# Altium schematic sheet RelayExpan.SchDoc
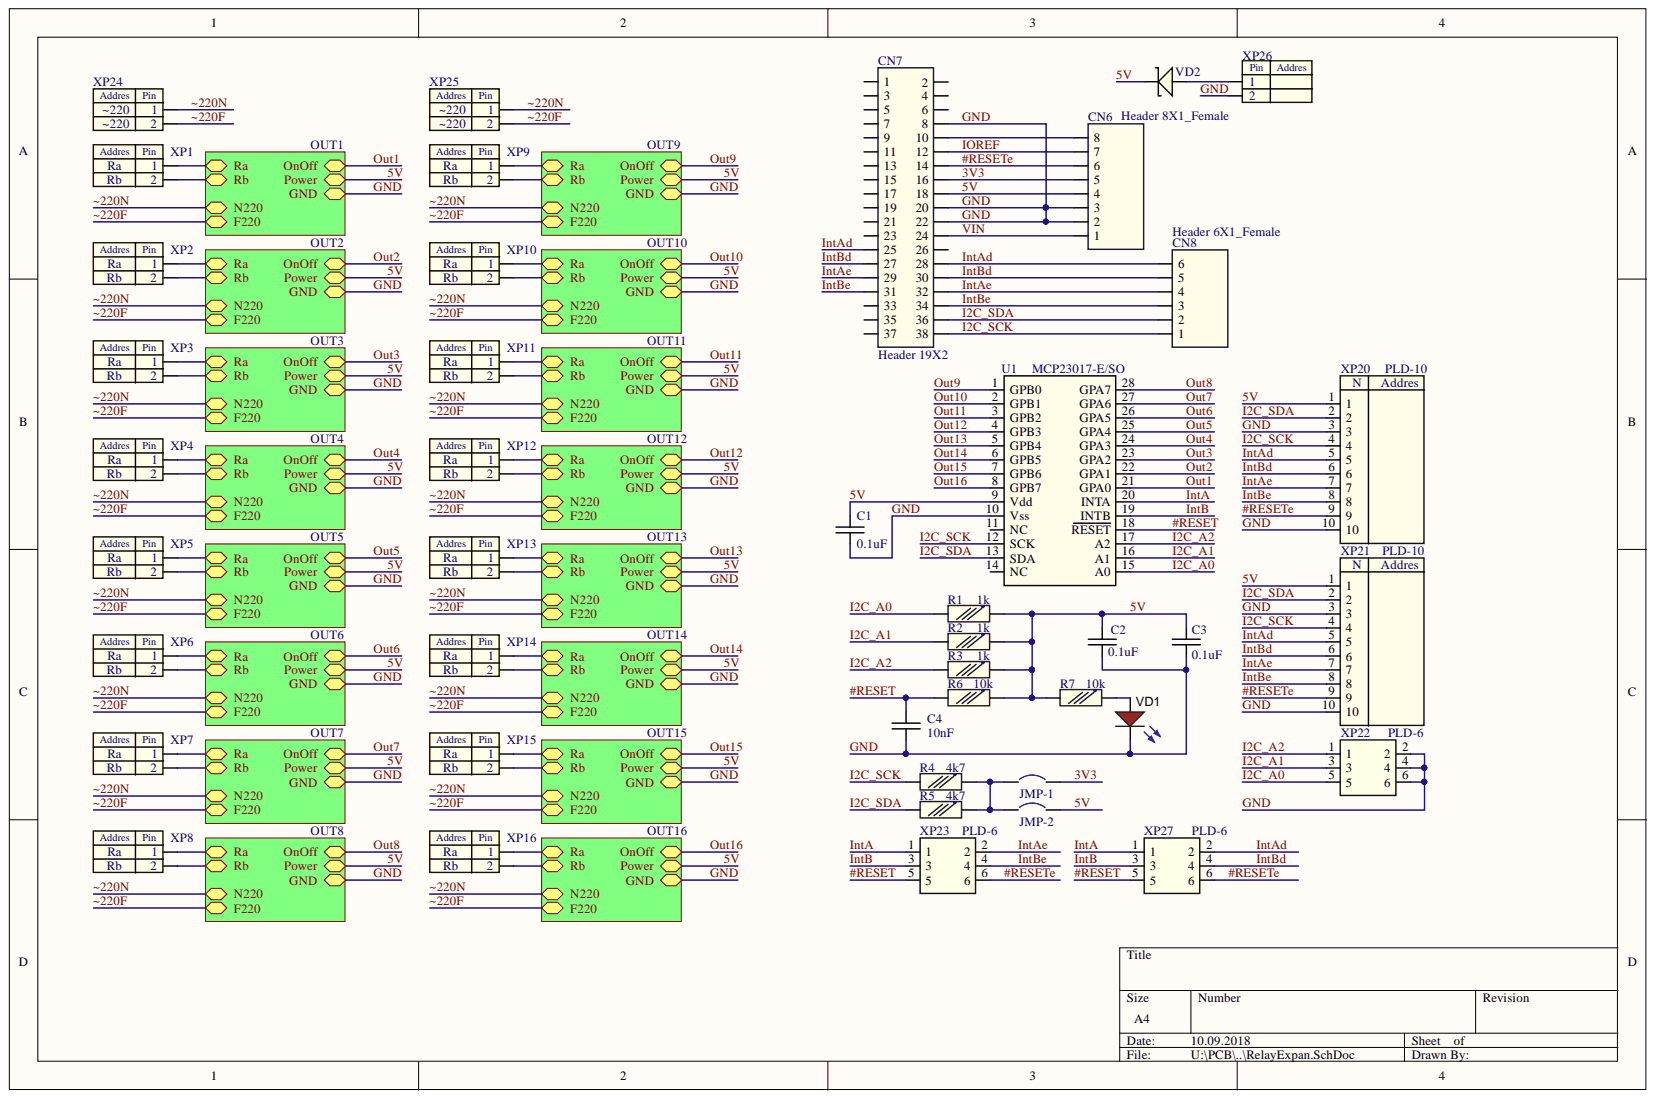
[diagram p0: RelayExpan.SchDoc]

## Parts
C1  0.1uF  [C0603]
C2  0.1uF  [C0603]
C3  0.1uF  [C0603]
C4  10nF  [C0603]
CN6  Header 8X1_Female  [1*8P_FEMALE]
CN7  Header 19X2  [2*19P]
CN8  Header 6X1_Female  [1*6P_FEMALE]
JMP-1  Circuit Breaker  [JP2_SMD]  (value not drawn)
JMP-2  Circuit Breaker  [JP2_SMD]  (value not drawn)
R1  1k  [R0603H-102]
R2  1k  [R0603H-102]
R3  1k  [R0603H-102]
R4  4k7  [R0603H-472]
R5  4k7  [R0603H-472]
R6  10k  [R0603H-103]
R7  10k  [R0603H-103]
U1  MCP23017-E/SO  [SOIC-28-300-MCP23017]
VD1  Red  [Led0603Red]  (value not drawn)
VD2  MBR0530  [SOD-123 - MBR0520T]  (value not drawn)
XP1  DG301-5.0  [DG301-5.0]  (value not drawn)
XP2  DG301-5.0  [DG301-5.0]  (value not drawn)
XP3  DG301-5.0  [DG301-5.0]  (value not drawn)
XP4  DG301-5.0  [DG301-5.0]  (value not drawn)
XP5  DG301-5.0  [DG301-5.0]  (value not drawn)
XP6  DG301-5.0  [DG301-5.0]  (value not drawn)
XP7  DG301-5.0  [DG301-5.0]  (value not drawn)
XP8  DG301-5.0  [DG301-5.0]  (value not drawn)
XP9  DG301-5.0  [DG301-5.0]  (value not drawn)
XP10  DG301-5.0  [DG301-5.0]  (value not drawn)
XP11  DG301-5.0  [DG301-5.0]  (value not drawn)
XP12  DG301-5.0  [DG301-5.0]  (value not drawn)
XP13  DG301-5.0  [DG301-5.0]  (value not drawn)
XP14  DG301-5.0  [DG301-5.0]  (value not drawn)
XP15  DG301-5.0  [DG301-5.0]  (value not drawn)
XP16  DG301-5.0  [DG301-5.0]  (value not drawn)
XP20  PLD-10  [PLD-10]
XP21  PLD-10  [PLD-10]
XP22  PLD-6  [PLD-6]
XP23  PLD-6  [PLD-6]
XP24  DG301-5.0  [DG301-5.0]  (value not drawn)
XP25  DG301-5.0  [DG301-5.0]  (value not drawn)
XP26  DG301-5.0  [DG301-5.0]  (value not drawn)
XP27  PLD-6  [PLD-6]

## Nets
3V3: CN6.5 CN7.16 JMP-1.2
5V: C1.2 C2.2 C3.2 CN6.4 CN7.18 JMP-2.2 R1.1 R2.1 R3.1 R6.1 R7.2 U1.9(Vdd) VD2.1 XP20.1 XP21.1
#RESET: C4.2 R6.2 U1.18(RESET) XP23.5 XP27.5
#RESETE: CN6.6 CN7.14 XP20.9 XP21.9 XP23.6 XP27.6
GND: C1.1 C2.1 C3.1 C4.1 CN6.2 CN6.3 CN7.8 CN7.20 CN7.22 U1.10(Vss) VD1.2 XP20.3 XP20.10 XP21.3 XP21.10 XP22.2 XP22.4 XP22.6 XP26.2
I2C_A0: R1.2 U1.15(A0) XP22.5
I2C_A1: R2.2 U1.16(A1) XP22.3
I2C_A2: R3.2 U1.17(A2) XP22.1
I2C_SCK: CN7.38 CN8.1 R4.2 U1.12(SCK) XP20.4 XP21.4
I2C_SDA: CN7.36 CN8.2 R5.2 U1.13(SDA) XP20.2 XP21.2
INTA: U1.20(INTA) XP23.1 XP27.1
INTAD: CN7.25 CN7.28 CN8.6 XP20.5 XP21.5 XP27.2
INTAE: CN7.29 CN7.32 CN8.4 XP20.7 XP21.7 XP23.2
INTB: U1.19(INTB) XP23.3 XP27.3
INTBD: CN7.27 CN7.30 CN8.5 XP20.6 XP21.6 XP27.4
INTBE: CN7.31 CN7.34 CN8.3 XP20.8 XP21.8 XP23.4
IOREF: CN6.7 CN7.12
OUT1: U1.21(GPA0)
OUT2: U1.22(GPA1)
OUT3: U1.23(GPA2)
OUT4: U1.24(GPA3)
OUT5: U1.25(GPA4)
OUT6: U1.26(GPA5)
OUT7: U1.27(GPA6)
OUT8: U1.28(GPA7)
OUT9: U1.1(GPB0)
OUT10: U1.2(GPB1)
OUT11: U1.3(GPB2)
OUT12: U1.4(GPB3)
OUT13: U1.5(GPB4)
OUT14: U1.6(GPB5)
OUT15: U1.7(GPB6)
OUT16: U1.8(GPB7)
VIN: CN6.1 CN7.24
~220F: XP24.2 XP25.2
~220N: XP24.1 XP25.1
NetCN6_8: CN6.8 CN7.10
NetCN7_1: CN7.1
NetCN7_2: CN7.2
NetCN7_3: CN7.3
NetCN7_4: CN7.4
NetCN7_5: CN7.5
NetCN7_6: CN7.6
NetCN7_7: CN7.7
NetCN7_9: CN7.9
NetCN7_11: CN7.11
NetCN7_13: CN7.13
NetCN7_15: CN7.15
NetCN7_17: CN7.17
NetCN7_19: CN7.19
NetCN7_21: CN7.21
NetCN7_23: CN7.23
NetCN7_26: CN7.26
NetCN7_33: CN7.33
NetCN7_35: CN7.35
NetCN7_37: CN7.37
NetJMP-1_1: JMP-1.1 JMP-2.1 R4.1 R5.1
NetR7_1: R7.1 VD1.1
NetU1_11: U1.11(NC)
NetU1_14: U1.14(NC)
NetVD2_2: VD2.2 XP26.1
NetXP1_1: XP1.1
NetXP1_2: XP1.2
NetXP2_1: XP2.1
NetXP2_2: XP2.2
NetXP3_1: XP3.1
NetXP3_2: XP3.2
NetXP4_1: XP4.1
NetXP4_2: XP4.2
NetXP5_1: XP5.1
NetXP5_2: XP5.2
NetXP6_1: XP6.1
NetXP6_2: XP6.2
NetXP7_1: XP7.1
NetXP7_2: XP7.2
NetXP8_1: XP8.1
NetXP8_2: XP8.2
NetXP9_1: XP9.1
NetXP9_2: XP9.2
NetXP10_1: XP10.1
NetXP10_2: XP10.2
NetXP11_1: XP11.1
NetXP11_2: XP11.2
NetXP12_1: XP12.1
NetXP12_2: XP12.2
NetXP13_1: XP13.1
NetXP13_2: XP13.2
NetXP14_1: XP14.1
NetXP14_2: XP14.2
NetXP15_1: XP15.1
NetXP15_2: XP15.2
NetXP16_1: XP16.1
NetXP16_2: XP16.2

## Library data of the parts
C1-C3 = C0603: 100nF 10% X7R 0603 SMD; Component Kind: Standard; ComponentLink1Description: Manufacturer Link; ComponentLink2Description: Datasheet; Library Name: Capacitors.SchLib; Value: 100nF 10% X7R 0603 SMD; ValueSch: 0.1uF
C4 = C0603: 10nF 10% X7R 0603 SMD; Component Kind: Standard; ComponentLink1Description: Manufacturer Link; ComponentLink2Description: Datasheet; Library Name: Capacitors.SchLib; Value: 10nF 10% X7R 0603 SMD; ValueSch: 10nF
CN6 = Header 8X1_Female: Header, 8-Pin, Single row,female; Component Kind: Standard; LatestRevisionDate: 17-Jul-2002; LatestRevisionNote: Re-released for DXP Platform.; Library Name: Connector.SchLib; Signal Integrity: Connector; Publisher: Altium Limited
CN7 = Header 19X2: Header, 19-Pin, Dual row; Component Kind: Standard; Library Name: Connector.SchLib; Revision: 17-Jul-2002: Re-released for DXP Platform.; Publisher: Altium Limited
CN8 = Header 6X1_Female: Header, 6-Pin, Single row,female; Component Kind: Standard; LatestRevisionDate: 17-Jul-2002; LatestRevisionNote: Re-released for DXP Platform.; Library Name: Connector.SchLib; Signal Integrity: Connector; Publisher: Altium Limited
JMP-1 JMP-2 = Circuit Breaker: Solder: NO; Component Kind: Standard; Description: Circuit Breaker; Library Name: Connector.SchLib; Published: 24-Mar-1999; Revision: July-2002: Re-released for DXP Platform.; Publisher: Altium Limited
R1-R3 = R0603: 1k 5% 0603 SMD; L_DB: ???; Component Kind: Standard; ComponentLink1Description: Manufacturer Link; ComponentLink2Description: Datasheet; Library Name: Resistors.SchLib; Value: 1k 5% 0603 SMD; ValueSCH: 1k
R4 R5 = R0603: 4k7 5% 0603 SMD; L_DB: ???; Component Kind: Standard; ComponentLink1Description: Manufacturer Link; ComponentLink2Description: Datasheet; Library Name: Resistors.SchLib; Value: 4k7 5% 0603 SMD; ValueSCH: 4k7
R6 R7 = R0603: 10k 5% 0603 SMD; L_DB: ???; Component Kind: Standard; ComponentLink1Description: Manufacturer Link; ComponentLink2Description: Datasheet; Library Name: Resistors.SchLib; Value: 10k 5% 0603 SMD; ValueSCH: 10k
U1 = MCP23017: MCP23017-E/SO SOIC-28-7.5; Component Kind: Standard; Library Name: Driver.SchLib; VelueSCH: MCP23017-E/SO
VD1 = Led0603Red: Ultra lighet RL-S190SC Super Red InGaN LED; L_DB: ???; Component Kind: Standard; ComponentLink2Description: Datasheet; Library Name: LED.SchLib; PackageReference: LED-0; PCB3D: Led0603_RL-S190SC_Red; ValueSCH: Red; ComponentLink2URL: C:\Project\Doc\Opto\CHIP_LED\HL-PT-1608U4FC-108.pdf; Publisher: Michael
VD2 = MBR0530: Диод SMD MBR0530PBF VISHAY; L_DB: ???; Component Kind: Standard; Library Name: Diodes.SchLib; ValueSCH: MBR0530
XP1-XP16 XP24-XP26 = DG301-5.0: L_DB: ???; Component Kind: Standard; Description: DG301-5.0; Library Name: Connector.SchLib; ValueSCH: DG301-5.0
XP20 XP21 = PLD-10: PLD-10 step 2.54 mm; Evaluate_Board: 1322xLPNRM; Component Kind: Standard; Library Name: Connector.SchLib
XP22 XP23 XP27 = PLD-6-2.54: 6PIN Connector Assignments pich 2.54; Evaluate_Board: Next01; Component Kind: Standard; Library Name: Connector.SchLib
